# Altium schematic sheet LTM8074neg.SchDoc
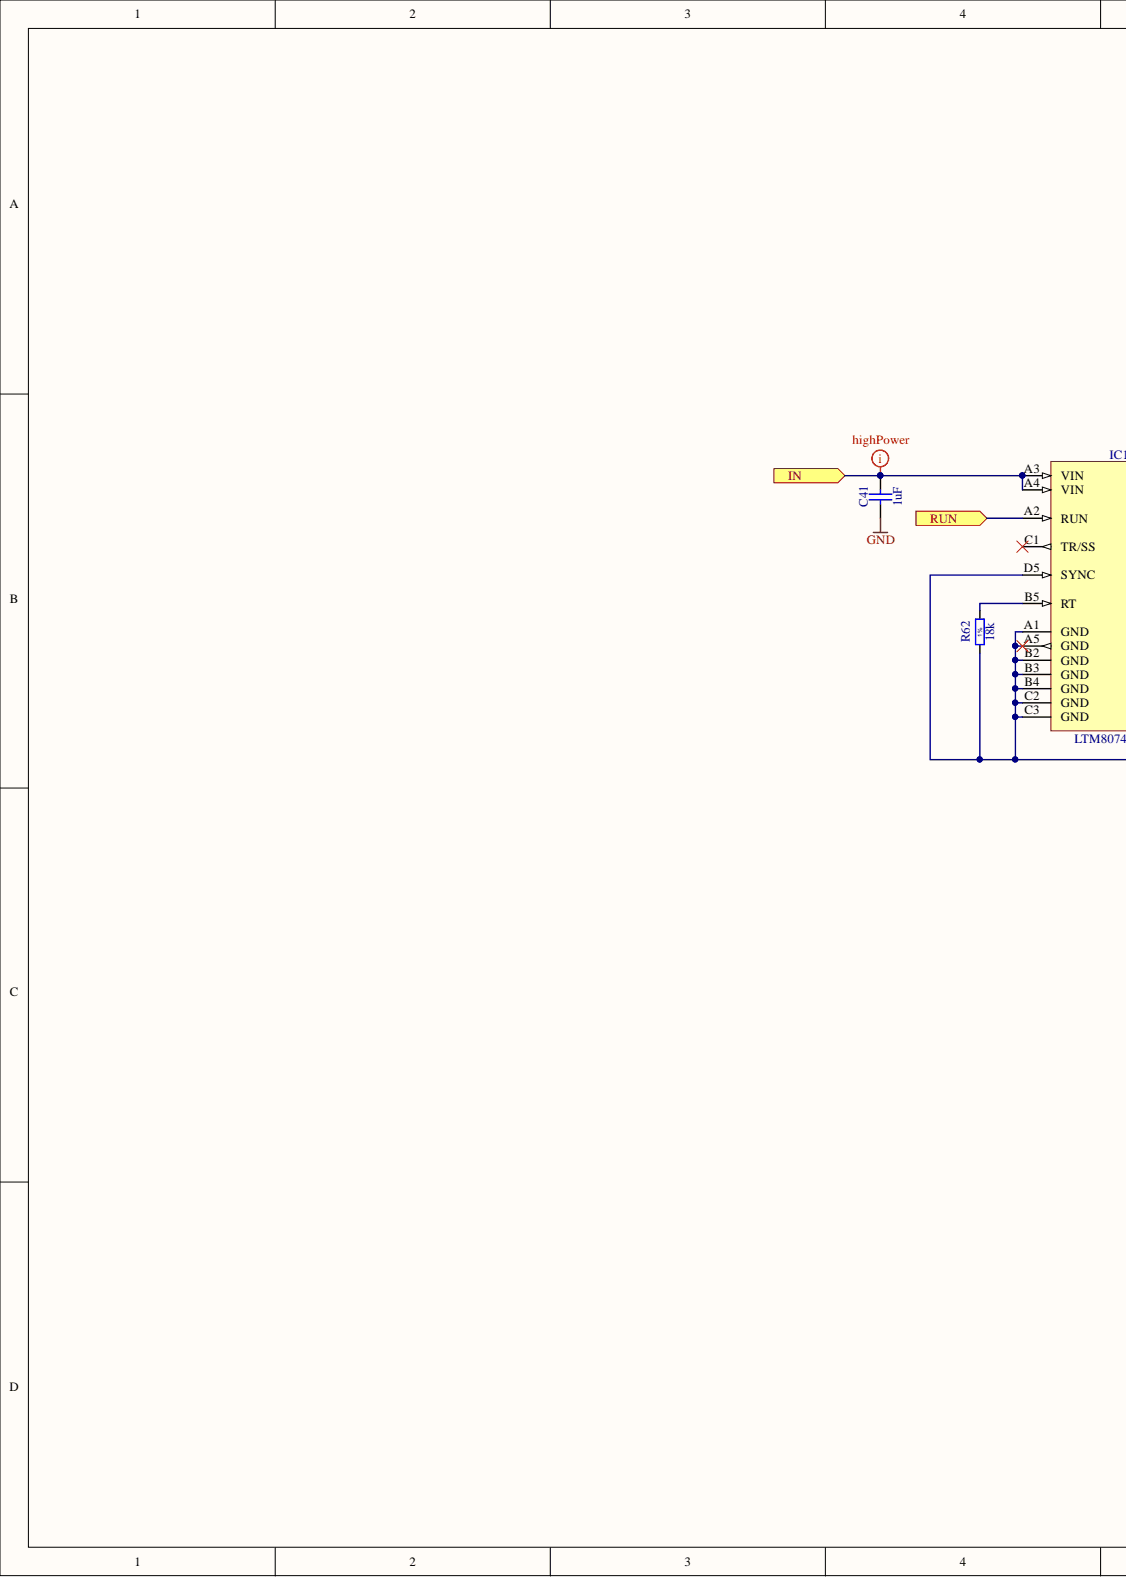
[diagram p0: LTM8074neg.SchDoc, left]
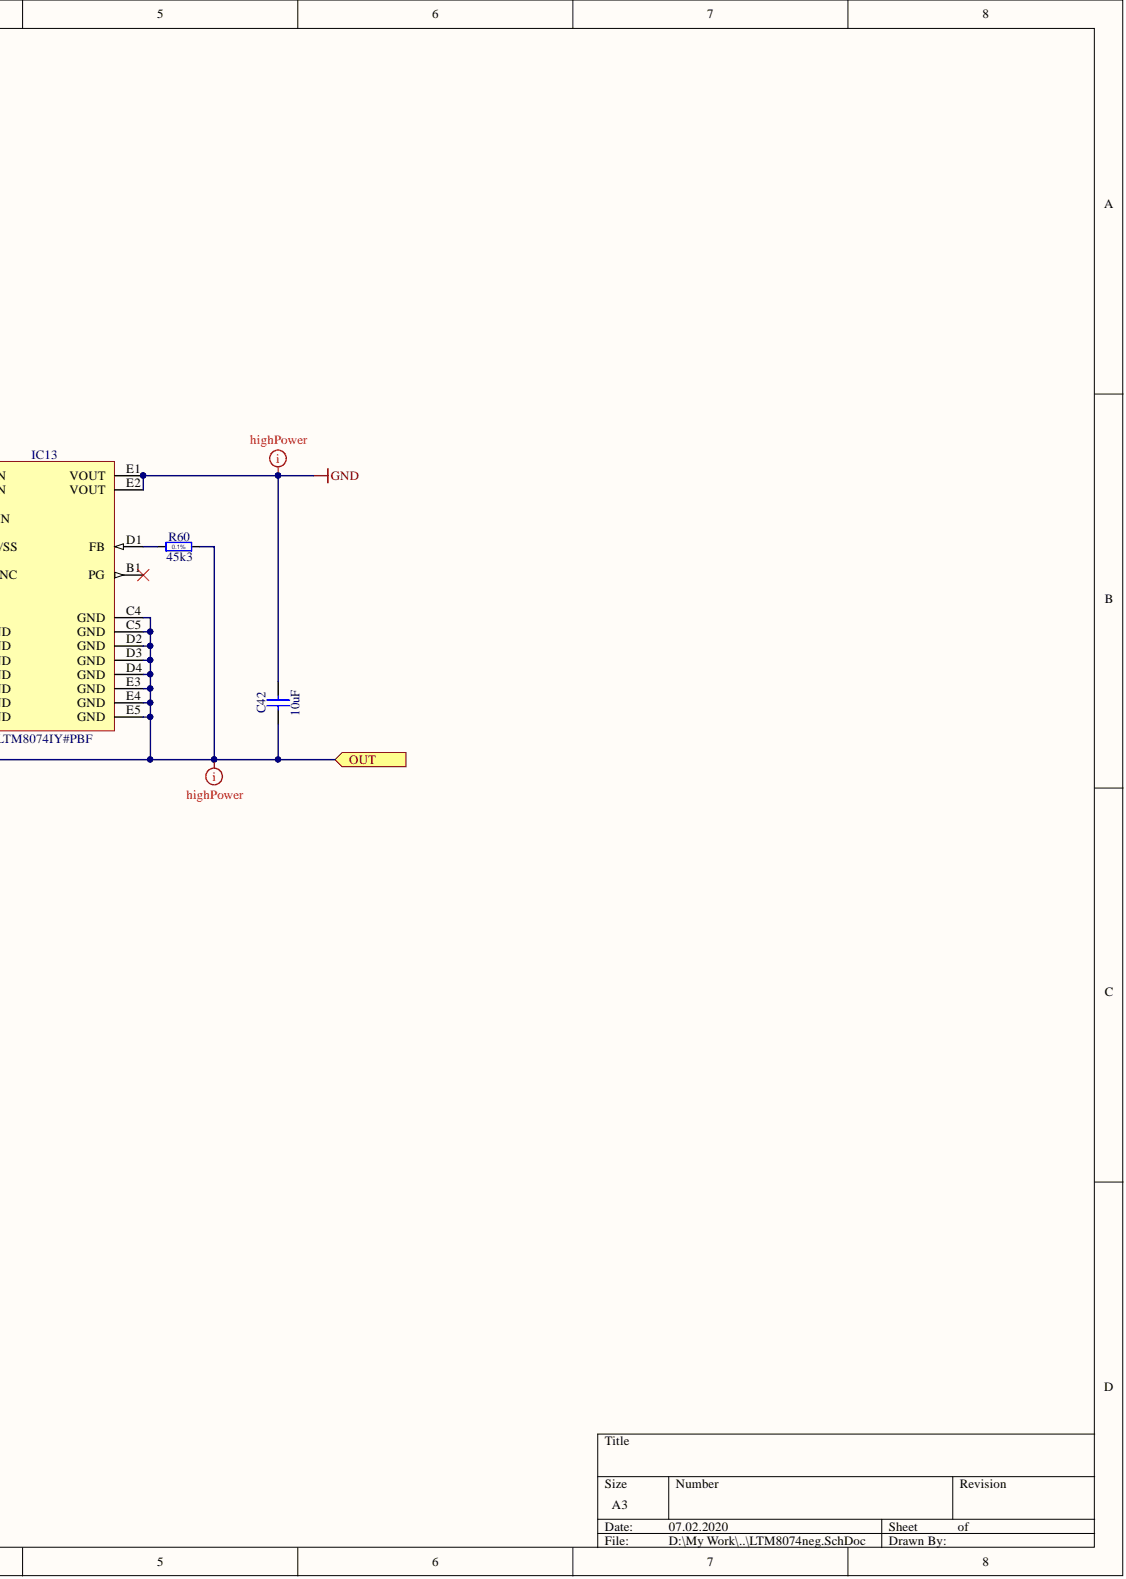
[diagram p1: LTM8074neg.SchDoc, right]

## Parts
C41  1uF  [CAPC2012X110N]
C42  10uF  [CAPC2012X95N]
IC13  LTM8074IY#PBF  [BGA25C65P5X5_400X400X198]
R60  45k3  [RESC1608X55N]
R62  18k  [RESC1608X55N]

## Ports
IN, OUT, RUN

## Nets
GND: C41.1 C42.2 IC13.E1(VOUT) IC13.E2(VOUT)
IN: C41.2 IC13.A3(VIN) IC13.A4(VIN) ; port IN
OUT: C42.1 IC13.A1(GND) IC13.A5(GND) IC13.B2(GND) IC13.B3(GND) IC13.B4(GND) IC13.C2(GND) IC13.C3(GND) IC13.C4(GND) IC13.C5(GND) IC13.D2(GND) IC13.D3(GND) IC13.D4(GND) IC13.D5(SYNC) IC13.E3(GND) IC13.E4(GND) IC13.E5(GND) R60.2 R62.1 ; port OUT
RUN: IC13.A2(RUN) ; port RUN
NetIC13_B5: IC13.B5(RT) R62.2
NetIC13_D1: IC13.D1(FB) R60.1

Not connected: IC13 B1(PG) C1(TR/SS)

## Library data of the parts
C41 = CC0805_1UF_50V_10%_X7R: Non-polarised capacitor; Manufacturer: GENERIC; Manufacturer1 Part Number: C0805C105K5RACTU; Part Description: SMD Multilayer Chip Ceramic Capacitor; Database Table Name: Capacitors SMD; TC: X7R; Tolerance: ±10%; Voltage: 50V; Case: 0805; Component Kind: Standard; Component Type: Standard; CreateDate: 04.12.2013; HelpURL: \\cern.ch\dfs\Applications\Altium\Datasheets\CC0805_X7R_KEMET.pdf; LatestRevisionDate: 04.12.2013; Library Name: Capacitors.DbLib; Manufacturer1 ComponentHeight: 1.1mm; Manufacturer1 Example: KEMET; Manufacturer Part Number: CC0805_1UF_50V_10%_X7R; Mounted: Yes; Part Number: CC0805_1UF_50V_10%_X7R; Pin Count: 2; Sense: No; SMD: Yes; Socket: No; Status: Preferred; Value: 1uF; Author: CERN DEM JLC
C42 = CC0805_10UF_25V_10%_X5R: Non-polarised capacitor; Manufacturer: GENERIC; Manufacturer1 Part Number: GRM219R61E106KA12D; Part Description: SMD Multilayer Chip Ceramic Capacitor; Database Table Name: Capacitors SMD; TC: X5R; Tolerance: ±10%; Voltage: 25V; Case: 0805; Component Kind: Standard; Component Type: Standard; CreateDate: 25.10.2012; HelpURL: \\cern.ch\dfs\Applications\Altium\Datasheets\CC0805_X5R_MURATA_GRM.pdf; LatestRevisionDate: 06.09.2016; Library Name: Capacitors.DbLib; Manufacturer1 ComponentHeight: 0.95mm; Manufacturer1 Example: MURATA; Manufacturer Part Number: CC0805_10UF_25V_10%_X5R; Mounted: Yes; Part Number: CC0805_10UF_25V_10%_X5R; Pin Count: 2; Sense: No; SMD: Yes; Socket: No; Status: Preferred; Value: 10uF; Author: CERN DEM JLC
IC13 = LTM8074IY#PBF: 40VIN, 1.2A Silent Switcher µModule Regulator; Manufacturer: LINEAR TECHNOLOGY; Bonding: No; Database Table Name: Regulators; PressFit: No; Case: BGA25; ComponentHeight: 1.98mm; Component Kind: Standard; Component Type: Standard; CreateDate: 08.04.2019; Device: LTM8074IY#PBF; HelpURL: \\cern.ch\dfs\Applications\Altium\Datasheets\LTM8074IY#PBF.pdf; LatestRevisionDate: 08.04.2019; Library Name: ICs And Semiconductors.DbLib; Manufacturer Part Number: LTM8074IY#PBF; Mounted: Yes; PackageDescription: BGA, 0.65mm Pitch, Square; 25 Pin, 5 Columns X 5 Rows, 4.00mm X 4.00mm X 1.98mm H; Part Description: 40VIN, 1.2A Silent Switcher µModule Regulator; Part Number: LTM8074IY#PBF; Pin Count: 25; Sense: No; SMD: Yes; Socket: No; Author: CERN DEM JLC
R60 = R0603_45K3_0.1%_0.063W_10PPM: Resistor - 0.1%; Manufacturer: GENERIC; Manufacturer1 Part Number: RN73C1J45K3BTDF; Part Description: Thin Film Chip Resistor; Database Table Name: Resistors SMD; Power: 0.063W; PressFit: No; TC: ±10ppm; Case: 0603; Component Kind: Standard; Component Type: Standard; CreateDate: 03.12.2007; HelpURL: \\cern.ch\dfs\Applications\Altium\Datasheets\R0603_Tyco_RN73.pdf; LatestRevisionDate: 13.03.2008; Library Name: Resistors.DbLib; Manufacturer1 ComponentHeight: 0.5mm; Manufacturer1 Example: TYCO HOLSWORTHY; Manufacturer Part Number: R0603_45K3_0.1%_0.063W_10PPM; Mounted: Yes; Part Number: R0603_45K3_0.1%_0.063W_10PPM; Pin Count: 2; Sense: No; SMD: Yes; Socket: No; Status: Not Recommended; Tolerance: ±0.1%; Value: 45k3; Author: CERN DEM JLC
R62 = R0603_18K_1%_0.1W_100PPM: Resistor - 1%; Manufacturer: GENERIC; Manufacturer1 Part Number: NRC06F1802TRF; Part Description: General Purpose Thick Film Chip Resistor; Database Table Name: Resistors SMD; Power: 0.1W; PressFit: No; TC: ±100ppm/°C; Case: 0603; Component Kind: Standard; Component Type: Standard; CreateDate: 03.12.2007; HelpURL: \\cern.ch\dfs\Applications\Altium\Datasheets\R0603_NIC_NRC.pdf; LatestRevisionDate: 13.03.2008; Library Name: Resistors.DbLib; Manufacturer1 ComponentHeight: 0.55mm; Manufacturer1 Example: NIC COMPONENT; Manufacturer Part Number: R0603_18K_1%_0.1W_100PPM; Mounted: Yes; Part Number: R0603_18K_1%_0.1W_100PPM; Pin Count: 2; Sense: No; SMD: Yes; Socket: No; Status: Preferred; Tolerance: ±1%; Value: 18k; Author: CERN DEM JLC
